# Altium schematic sheet SCH005 - VSC742x SERDES, VSC8574,clock.SchDoc
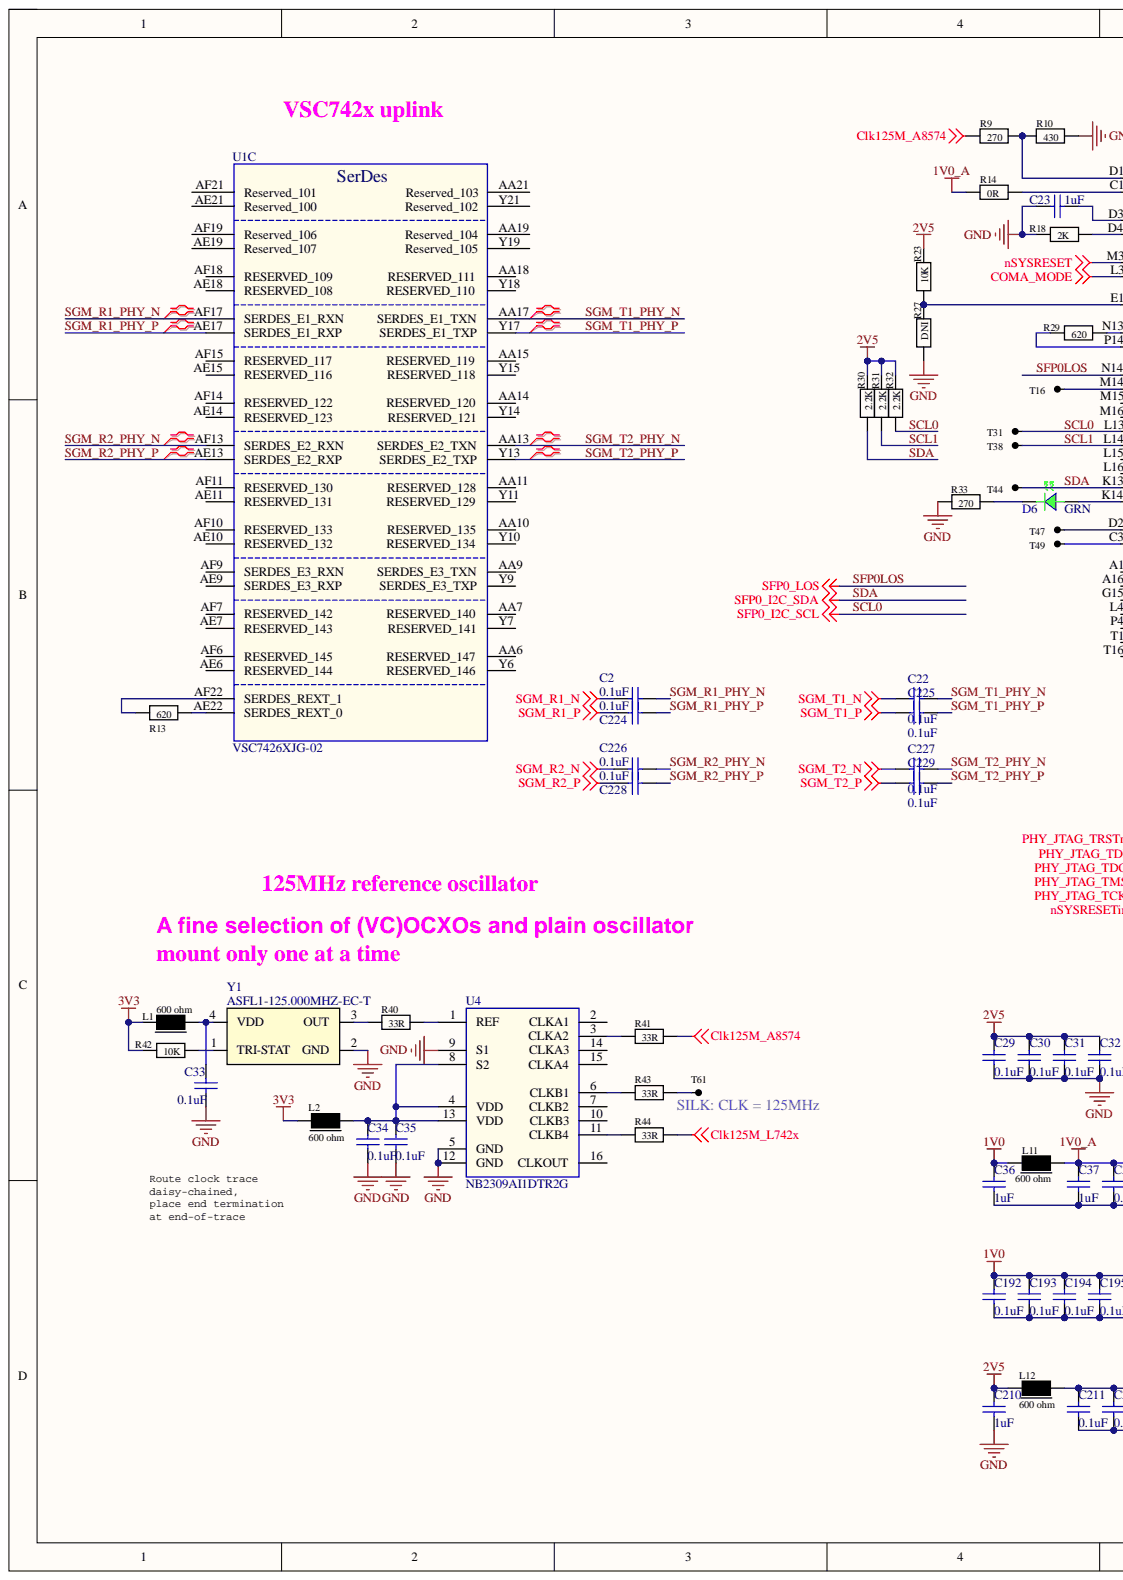
[diagram p0: SCH005 - VSC742x SERDES, VSC8574,clock.SchDoc, left]
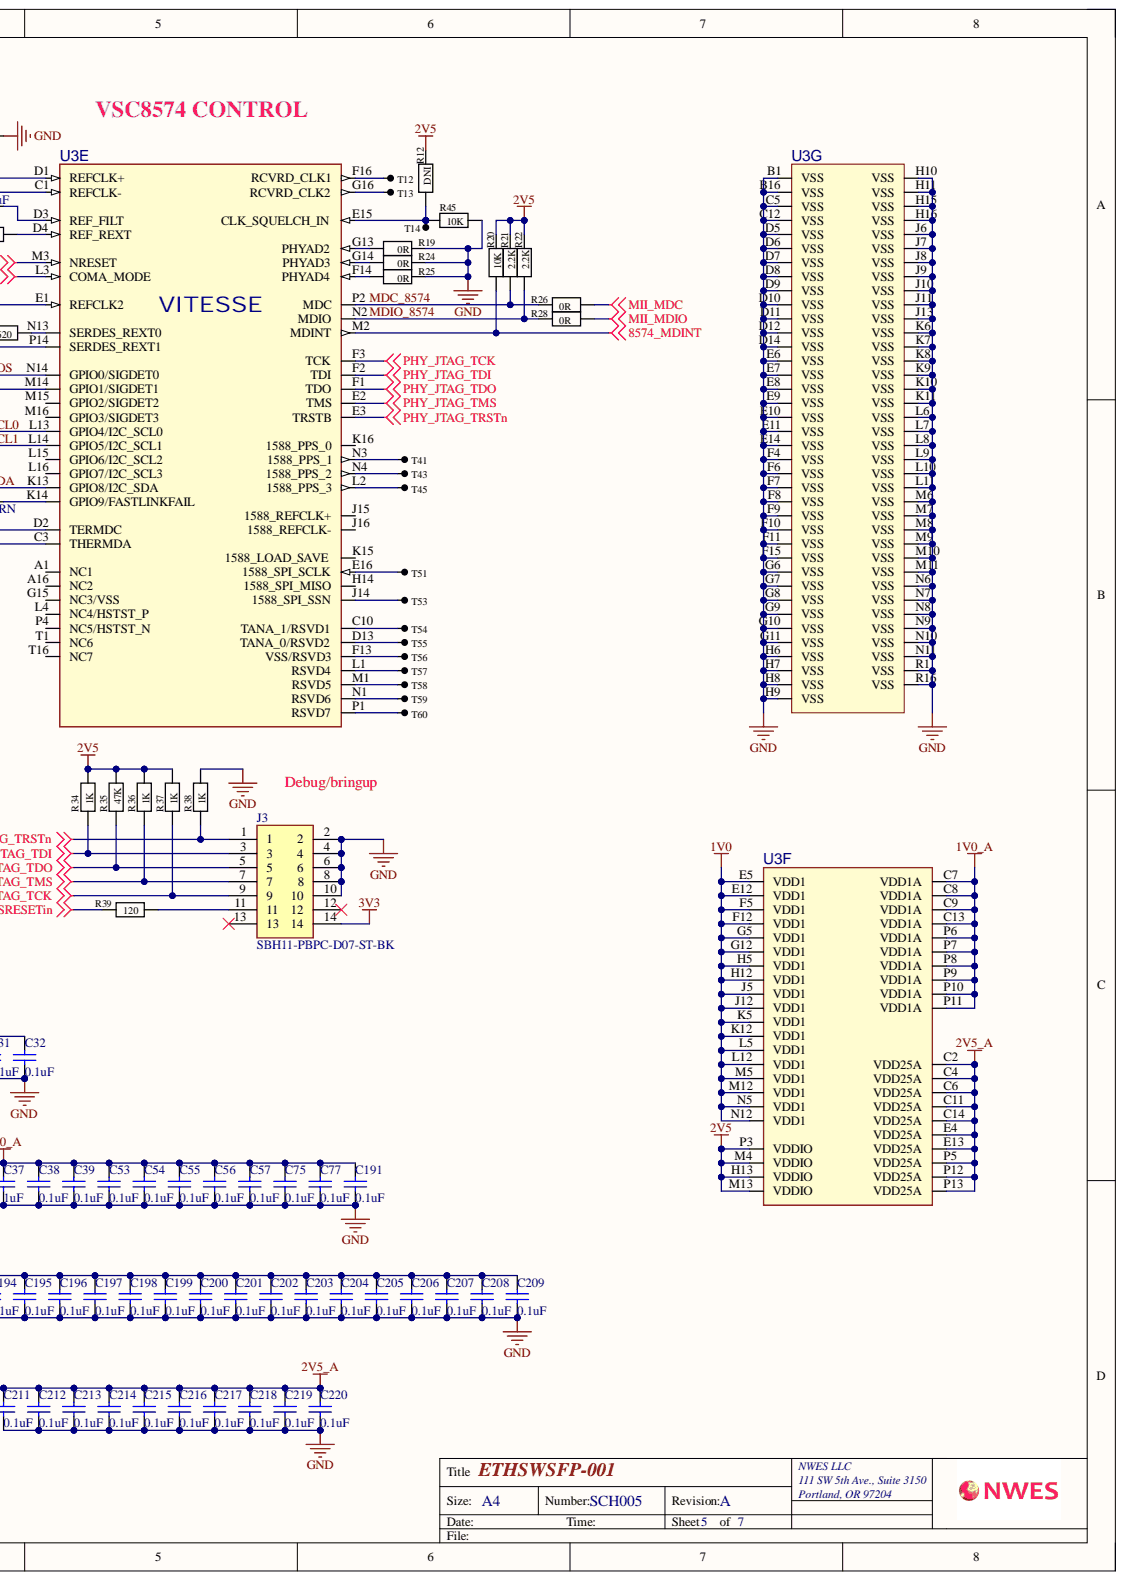
[diagram p1: SCH005 - VSC742x SERDES, VSC8574,clock.SchDoc, right]

## Parts
C2  0.1uF  [CAPC1005X55USR]
C22  0.1uF  [CAPC1005X55USR]
C23  1uF  [CAPC1005X61USR]
C29  0.1uF  [CAPC1005X61USR]
C30  0.1uF  [CAPC1005X61USR]
C31  0.1uF  [CAPC1005X61USR]
C32  0.1uF  [CAPC1005X61USR]
C33  0.1uF  [CAPC1005X61USR]
C34  0.1uF  [CAPC1005X61USR]
C35  0.1uF  [CAPC1005X61USR]
C36  1uF  [CAPC1005X61USR]
C37  1uF  [CAPC1005X61USR]
C38  0.1uF  [CAPC1005X61USR]
C39  0.1uF  [CAPC1005X61USR]
C53  0.1uF  [CAPC1005X61USR]
C54  0.1uF  [CAPC1005X61USR]
C55  0.1uF  [CAPC1005X61USR]
C56  0.1uF  [CAPC1005X61USR]
C57  0.1uF  [CAPC1005X61USR]
C75  0.1uF  [CAPC1005X61USR]
C77  0.1uF  [CAPC1005X61USR]
C191  0.1uF  [CAPC1005X61USR]
C192  0.1uF  [CAPC1005X61USR]
C193  0.1uF  [CAPC1005X61USR]
C194  0.1uF  [CAPC1005X61USR]
C195  0.1uF  [CAPC1005X61USR]
C196  0.1uF  [CAPC1005X61USR]
C197  0.1uF  [CAPC1005X61USR]
C198  0.1uF  [CAPC1005X61USR]
C199  0.1uF  [CAPC1005X61USR]
C200  0.1uF  [CAPC1005X61USR]
C201  0.1uF  [CAPC1005X61USR]
C202  0.1uF  [CAPC1005X61USR]
C203  0.1uF  [CAPC1005X61USR]
C204  0.1uF  [CAPC1005X61USR]
C205  0.1uF  [CAPC1005X61USR]
C206  0.1uF  [CAPC1005X61USR]
C207  0.1uF  [CAPC1005X61USR]
C208  0.1uF  [CAPC1005X61USR]
C209  0.1uF  [CAPC1005X61USR]
C210  1uF  [CAPC1005X61USR]
C211  0.1uF  [CAPC1005X61USR]
C212  0.1uF  [CAPC1005X61USR]
C213  0.1uF  [CAPC1005X61USR]
C214  0.1uF  [CAPC1005X61USR]
C215  0.1uF  [CAPC1005X61USR]
C216  0.1uF  [CAPC1005X61USR]
C217  0.1uF  [CAPC1005X61USR]
C218  0.1uF  [CAPC1005X61USR]
C219  0.1uF  [CAPC1005X61USR]
C220  0.1uF  [CAPC1005X61USR]
C224  0.1uF  [CAPC1005X55USR]
C225  0.1uF  [CAPC1005X55USR]
C226  0.1uF  [CAPC1005X55USR]
C227  0.1uF  [CAPC1005X55USR]
C228  0.1uF  [CAPC1005X55USR]
C229  0.1uF  [CAPC1005X55USR]
D6  GRN  [LED_0603]
J3  SBH11-PBPC-D07-ST-BK  [SULLINS_SBH11-PBPC-D07-ST-BK]
L1  600 ohm  [0603_FB]
L2  600 ohm  [0603_FB]
L11  600 ohm  [0603_FB]
L12  600 ohm  [0603_FB]
R9  270  [RESC1005X40USR]
R10  430  [RESC1005X40USR]
R12  DNI  [RESC1005X40USR]
R13  620  [RESC1005X40USR]
R14  0R  [RESC1005X40USR]
R18  2K  [RESC1005X40USR]
R19  0R  [RESC1005X40USR]
R20  10K  [RESC1005X40USR]
R21  2.2K  [RESC1005X40USR]
R22  2.2K  [RESC1005X40USR]
R23  10K  [RESC1005X40USR]
R24  0R  [RESC1005X40USR]
R25  0R  [RESC1005X40USR]
R26  0R  [RESC1005X40USR]
R27  DNI  [RESC1005X40USR]
R28  0R  [RESC1005X40USR]
R29  620  [RESC1005X40USR]
R30  2.2K  [RESC1005X40USR]
R31  2.2K  [RESC1005X40USR]
R32  2.2K  [RESC1005X40USR]
R33  270  [RESC1005X40USR]
R34  1K  [RESC1005X40USR]
R35  47K  [RESC1005X40USR]
R36  1K  [RESC1005X40USR]
R37  1K  [RESC1005X40USR]
R38  1K  [RESC1005X40USR]
R39  120  [RESC1005X40USR]
R40  33R  [RESC1005X40USR]
R41  33R  [RESC1005X40USR]
R42  10K  [RESC1005X40USR]
R43  33R  [RESC1005X40USR]
R44  33R  [RESC1005X40USR]
R45  10K  [RESC1005X40USR]
T12  TP_S  [TP 1mm]  (value not drawn)  (no BOM)
T13  TP_S  [TP 1mm]  (value not drawn)  (no BOM)
T14  TP_S  [TP 1mm]  (value not drawn)  (no BOM)
T16  TP_S  [TP 1mm]  (value not drawn)  (no BOM)
T31  TP_S  [TP 1mm]  (value not drawn)  (no BOM)
T38  TP_S  [TP 1mm]  (value not drawn)  (no BOM)
T41  TP_S  [TP 1mm]  (value not drawn)  (no BOM)
T43  TP_S  [TP 1mm]  (value not drawn)  (no BOM)
T44  TP_S  [TP 1mm]  (value not drawn)  (no BOM)
T45  TP_S  [TP 1mm]  (value not drawn)  (no BOM)
T47  TP_S  [TP 1mm]  (value not drawn)  (no BOM)
T49  TP_S  [TP 1mm]  (value not drawn)  (no BOM)
T51  TP_S  [TP 1mm]  (value not drawn)  (no BOM)
T53  TP_S  [TP 1mm]  (value not drawn)  (no BOM)
T54  TP_S  [TP 1mm]  (value not drawn)  (no BOM)
T55  TP_S  [TP 1mm]  (value not drawn)  (no BOM)
T56  TP_S  [TP 1mm]  (value not drawn)  (no BOM)
T57  TP_S  [TP 1mm]  (value not drawn)  (no BOM)
T58  TP_S  [TP 1mm]  (value not drawn)  (no BOM)
T59  TP_S  [TP 1mm]  (value not drawn)  (no BOM)
T60  TP_S  [TP 1mm]  (value not drawn)  (no BOM)
T61  TP_S  [TP 1mm]  (value not drawn)  (no BOM)
U1  VSC7426XJG-02  [BGA672C100P26X26_2700X2700X244]  (part C)
U3  VSC8574XKS-01  [256-BGA_1700X1700]  (value not drawn)  (parts E,F,G)
U4  NB2309AI1DTR2G  [NB2309AI1DT_TSSOP-16_ONS]
Y1  ASFL1-125.000MHZ-EC-T  [XTAL_50X32X130]

## Nets
1V0: C36.2 C192.1 C193.1 C194.1 C195.1 C196.1 C197.1 C198.1 C199.1 C200.1 C201.1 C202.1 C203.1 C204.1 C205.1 C206.1 C207.1 C208.1 C209.1 L11.1 U3.E5(VDD1) U3.E12(VDD1) U3.F5(VDD1) U3.F12(VDD1) U3.G5(VDD1) U3.G12(VDD1) U3.H5(VDD1) U3.H12(VDD1) U3.J5(VDD1) U3.J12(VDD1) U3.K5(VDD1) U3.K12(VDD1) U3.L5(VDD1) U3.L12(VDD1) U3.M5(VDD1) U3.M12(VDD1) U3.N5(VDD1) U3.N12(VDD1)
1V0_A: C37.2 C38.1 C39.1 C53.1 C54.1 C55.1 C56.1 C57.1 C75.1 C77.1 C191.1 L11.2 R14.2 U3.C7(VDD1A) U3.C8(VDD1A) U3.C9(VDD1A) U3.C13(VDD1A) U3.P6(VDD1A) U3.P7(VDD1A) U3.P8(VDD1A) U3.P9(VDD1A) U3.P10(VDD1A) U3.P11(VDD1A)
2V5: C29.1 C30.1 C31.1 C32.1 C210.2 L12.2 R12.1 R20.2 R21.2 R22.2 R23.1 R30.1 R31.1 R32.1 R34.1 R35.1 R36.1 R37.1 U3.H13(VDDIO) U3.M4(VDDIO) U3.M13(VDDIO) U3.P3(VDDIO)
2V5_A: C211.1 C212.1 C213.1 C214.1 C215.1 C216.1 C217.1 C218.1 C219.1 C220.1 L12.1 U3.C2(VDD25A) U3.C4(VDD25A) U3.C6(VDD25A) U3.C11(VDD25A) U3.C14(VDD25A) U3.E4(VDD25A) U3.E13(VDD25A) U3.P5(VDD25A) U3.P12(VDD25A) U3.P13(VDD25A)
3V3: J3.14 L1.1 L2.1 R42.1
8574_MDINT: R20.1 U3.M2(MDINT)
Clk125M_A8574: R9.2 R41.1
Clk125M_L742x: R44.1
COMA_MODE: U3.L3(COMA_MODE)
GND: C23.2 C29.2 C30.2 C31.2 C32.2 C33.1 C34.1 C35.1 C36.1 C37.1 C38.2 C39.2 C53.2 C54.2 C55.2 C56.2 C57.2 C75.2 C77.2 C191.2 C192.2 C193.2 C194.2 C195.2 C196.2 C197.2 C198.2 C199.2 C200.2 C201.2 C202.2 C203.2 C204.2 C205.2 C206.2 C207.2 C208.2 C209.2 C210.1 C211.2 C212.2 C213.2 C214.2 C215.2 C216.2 C217.2 C218.2 C219.2 C220.2 J3.2 J3.4 J3.6 J3.8 J3.10 R10.1 R18.2 R19.1 R24.1 R25.1 R27.2 R33.1 R38.1 R45.1 U3.B1(VSS) U3.B16(VSS) U3.C5(VSS) U3.C12(VSS) U3.D5(VSS) U3.D6(VSS) U3.D7(VSS) U3.D8(VSS) U3.D9(VSS) U3.D10(VSS) U3.D11(VSS) U3.D12(VSS) U3.D14(VSS) U3.E6(VSS) U3.E7(VSS) U3.E8(VSS) U3.E9(VSS) U3.E10(VSS) U3.E11(VSS) U3.E14(VSS) U3.F4(VSS) U3.F6(VSS) U3.F7(VSS) U3.F8(VSS) U3.F9(VSS) U3.F10(VSS) U3.F11(VSS) U3.F15(VSS) U3.G6(VSS) U3.G7(VSS) U3.G8(VSS) U3.G9(VSS) U3.G10(VSS) U3.G11(VSS) U3.H6(VSS) U3.H7(VSS) U3.H8(VSS) U3.H9(VSS) U3.H10(VSS) U3.H11(VSS) U3.H15(VSS) U3.H16(VSS) U3.J6(VSS) U3.J7(VSS) U3.J8(VSS) U3.J9(VSS) U3.J10(VSS) U3.J11(VSS) U3.J13(VSS) U3.K6(VSS) U3.K7(VSS) U3.K8(VSS) U3.K9(VSS) U3.K10(VSS) U3.K11(VSS) U3.L6(VSS) U3.L7(VSS) U3.L8(VSS) U3.L9(VSS) U3.L10(VSS) U3.L11(VSS) U3.M6(VSS) U3.M7(VSS) U3.M8(VSS) U3.M9(VSS) U3.M10(VSS) U3.M11(VSS) U3.N6(VSS) U3.N7(VSS) U3.N8(VSS) U3.N9(VSS) U3.N10(VSS) U3.N11(VSS) U3.R1(VSS) U3.R16(VSS) U4.5(GND) U4.9(S1) U4.12(GND) Y1.2(GND)
MII_MDC: R26.1
MII_MDIO: R28.1
nSYSRESET: U3.M3(NRESET)
nSYSRESETin: R39.1
PHY_JTAG_TCK: J3.9 R37.2 U3.F3(TCK)
PHY_JTAG_TDI: J3.3 R34.2 U3.F2(TDI)
PHY_JTAG_TDO: J3.5 R35.2 U3.F1(TDO)
PHY_JTAG_TMS: J3.7 R36.2 U3.E2(TMS)
PHY_JTAG_TRSTn: J3.1 R38.2 U3.E3(TRSTB)
SGM_R1_N: C2.2
SGM_R1_P: C224.2
SGM_R2_N: C226.2
SGM_R2_P: C228.2
SGM_T1_N: C22.1
SGM_T1_P: C225.1
SGM_T2_N: C227.1
SGM_T2_P: C229.1
MDC_8574: R21.1 R26.2 U3.P2(MDC)
MDIO_8574: R22.1 R28.2 U3.N2(MDIO)
SCL0: R32.2 T31.1 U3.L13(GPIO4/I2C_SCL0)
SCL1: R31.2 T38.1 U3.L14(GPIO5/I2C_SCL1)
SDA: R30.2 T44.1 U3.K13(GPIO8/I2C_SDA)
SFP0LOS: U3.N14(GPIO0/SIGDET0)
SGM_R1_PHY_N: C2.1 U1.AF17(SERDES_E1_RXN)
SGM_R1_PHY_P: C224.1 U1.AE17(SERDES_E1_RXP)
SGM_R2_PHY_N: C226.1 U1.AF13(SERDES_E2_RXN)
SGM_R2_PHY_P: C228.1 U1.AE13(SERDES_E2_RXP)
SGM_T1_PHY_N: C22.2 U1.AA17(SERDES_E1_TXN)
SGM_T1_PHY_P: C225.2 U1.Y17(SERDES_E1_TXP)
SGM_T2_PHY_N: C227.2 U1.AA13(SERDES_E2_TXN)
SGM_T2_PHY_P: C229.2 U1.Y13(SERDES_E2_TXP)
NetC23_1: C23.1 U3.D3(REF_FILT)
NetC33_2: C33.2 L1.2 Y1.4(VDD)
NetC34_2: C34.2 C35.2 L2.2 U4.4(VDD) U4.8(S2) U4.13(VDD)
NetD6_1: D6.1 U3.K14(GPIO9/FASTLINKFAIL)
NetD6_2: D6.2 R33.2
NetJ3_11: J3.11 R39.2
NetR9_1: R9.1 R10.2 U3.D1(REFCLK+)
NetR12_2: R12.2 R45.2 T14.1 U3.E15(CLK_SQUELCH_IN)
NetR13_1: R13.1 U1.AE22(SERDES_REXT_0)
NetR13_2: R13.2 U1.AF22(SERDES_REXT_1)
NetR14_1: R14.1 U3.C1(REFCLK-)
NetR18_1: R18.1 U3.D4(REF_REXT)
NetR19_2: R19.2 U3.G13(PHYAD2)
NetR23_2: R23.2 R27.1 U3.E1(REFCLK2)
NetR24_2: R24.2 U3.G14(PHYAD3)
NetR25_2: R25.2 U3.F14(PHYAD4)
NetR29_1: R29.1 U3.N13(SERDES_REXT0)
NetR29_2: R29.2 U3.P14(SERDES_REXT1)
NetR40_1: R40.1 U4.1(REF)
NetR40_2: R40.2 Y1.3(OUT)
NetR41_2: R41.2 U4.3(CLKA2)
NetR42_2: R42.2 Y1.1(TRI-STAT)
NetR43_1: R43.1 T61.1
NetR43_2: R43.2 U4.6(CLKB1)
NetR44_2: R44.2 U4.11(CLKB4)
NetT12_1: T12.1 U3.F16(RCVRD_CLK1)
NetT13_1: T13.1 U3.G16(RCVRD_CLK2)
NetT16_1: T16.1 U3.M14(GPIO1/SIGDET1)
NetT41_1: T41.1 U3.N3(1588_PPS_1)
NetT43_1: T43.1 U3.N4(1588_PPS_2)
NetT45_1: T45.1 U3.L2(1588_PPS_3)
NetT47_1: T47.1 U3.D2(TERMDC)
NetT49_1: T49.1 U3.C3(THERMDA)
NetT51_1: T51.1 U3.E16(1588_SPI_SCLK)
NetT53_1: T53.1 U3.J14(1588_SPI_SSN)
NetT54_1: T54.1 U3.C10(TANA_1/RSVD1)
NetT55_1: T55.1 U3.D13(TANA_0/RSVD2)
NetT56_1: T56.1 U3.F13(VSS/RSVD3)
NetT57_1: T57.1 U3.L1(RSVD4)
NetT58_1: T58.1 U3.M1(RSVD5)
NetT59_1: T59.1 U3.N1(RSVD6)
NetT60_1: T60.1 U3.P1(RSVD7)

Not connected: J3 12 13; U1 AA6(RESERVED_147) AA7(RESERVED_140) AA9(SERDES_E3_TXN) AA10(RESERVED_135) AA11(RESERVED_128) AA14(RESERVED_120) AA15(RESERVED_119) AA18(RESERVED_111) AA19(Reserved_104) AA21(Reserved_103) AE6(RESERVED_144) AE7(RESERVED_143) AE9(SERDES_E3_RXP) AE10(RESERVED_132) AE11(RESERVED_131) AE14(RESERVED_123) AE15(RESERVED_116) AE18(RESERVED_108) AE19(Reserved_107) AE21(Reserved_100) AF6(RESERVED_145) AF7(RESERVED_142) AF9(SERDES_E3_RXN) AF10(RESERVED_133) AF11(RESERVED_130) AF14(RESERVED_122) AF15(RESERVED_117) AF18(RESERVED_109) AF19(Reserved_106) AF21(Reserved_101) Y6(RESERVED_146) Y7(RESERVED_141) Y9(SERDES_E3_TXP) Y10(RESERVED_134) Y11(RESERVED_129) Y14(RESERVED_121) Y15(RESERVED_118) Y18(RESERVED_110) Y19(Reserved_105) Y21(Reserved_102); U3 A1(NC1) A16(NC2) G15(NC3/VSS) H14(1588_SPI_MISO) J15(1588_REFCLK+) J16(1588_REFCLK-) K15(1588_LOAD_SAVE) K16(1588_PPS_0) L4(NC4/HSTST_P) L15(GPIO6/I2C_SCL2) L16(GPIO7/I2C_SCL3) M15(GPIO2/SIGDET2) M16(GPIO3/SIGDET3) P4(NC5/HSTST_N) T1(NC6) T16(NC7); U4 2(CLKA1) 7(CLKB2) 10(CLKB3) 14(CLKA3) 15(CLKA4) 16(CLKOUT)

## Library data of the parts
C2 C22 C224-C229 = CAP CER 0.1UF 25V X5R 0402: Manufacturer: Samsung Electro-Mechanics; Manufacturer Part Number: CL05A104KA5NNNC; Component Kind: Standard; Description: CAP CER 0.1UF 25V X5R 0402; Height: 0.55mm; Package: 0402; Supplier 1: Digi-Key; Supplier Part Number 1: 1276-1043-1-ND; value: 0.1uF; Library Name: Radar FPGA RF.SCHLIB
C23 C36 C37 C210 = CAP CER 1UF 25V X5R 0402: Manufacturer: Murata Electronics; Manufacturer Part Number: GRM155R61E105MA12D; Component Kind: Standard; Description: CAP CER 1UF 25V X5R 0402; Package: 0402; Supplier 1: Digi-Key; Supplier Part Number 1: 490-10018-1-ND; value: 1uF; Library Name: 15-port switch V1.SCHLIB
C29-C35 C38 C39 C53-C57 C75 C77 C191-C209 C211-C220 = CAP CER 0.1UF 50V X7R 0402: Manufacturer: Murata Electronics; Manufacturer Part Number: GRM155R71H104KE14D; Component Kind: Standard; Description: CAP CER 0.1UF 50V X7R 0402; Package: 0402; Supplier 1: Digi-Key; Supplier Part Number 1: 490-10700-2-ND; value: 0.1uF; Library Name: 15-port switch V1.SCHLIB
D6 = 0603LED_GRN: LED GREEN CLEAR CHIP 0603 SMD; Manufacturer: Lite-On Inc.; Manufacturer Part Number: LTST-C191GKT; Unit Cost, Qty 1: $0.68; Unit Cost, Qty 500: $0.293; Component Kind: Standard; Supplier 1: Digi-Key; Supplier Part Number 1: 160-1443-1-ND; Value: GRN; Library Name: 15-port switch V1.SCHLIB
J3 = SBH11-PBPC-D07-ST-BK: Connector Header Through Hole 14 position 0.100 _2.54mm_; Manufacturer: Sullins Connector Solutions; Component Kind: Standard; Manufacturer Part Number: SBH11-PBPC-D07-ST-BK; Supplier 1: Digi-Key; Supplier Part Number 1: S9170-ND; Value: SBH11-PBPC-D07-ST-BK; Library Name: 15-port switch V1.SCHLIB
L1 L2 L11 L12 = FERRITE BEAD 600 OHM 0603 1LN: Manufacturer: Würth Elektronik; Manufacturer Part Number: 742863160; Component Kind: Standard; Description: FERRITE BEAD 600 OHM 0603 1LN; Package: 0603; Supplier 1: Digi-Key; Supplier Part Number 1: 732-2389-1-ND; Value: 600 ohm; Library Name: 15-port switch V1.SCHLIB
R9 R33 = RES 270 OHM 1% 1/16W 0402: Manufacturer: Yageo; Manufacturer Part Number: RC0402FR-07270RL; Component Kind: Standard; Description: RES 270 OHM 1% 1/16W 0402; Height: 0.40mm; Package: 0402; Supplier 1: Digi-Key; Supplier Part Number 1: 311-270LRCT-ND; Value: 270; Library Name: 15-port switch V1.SCHLIB
R10 = RES 430 OHM 1% 1/16W 0402: Manufacturer: Yageo; Manufacturer Part Number: RC0402FR-07430RL; Component Kind: Standard; Description: RES 430 OHM 1% 1/16W 0402; Height: 0.40mm; Package: 0402; Supplier 1: Digi-Key; Supplier Part Number 1: 311-430LRCT-ND; Value: 430; Library Name: 15-port switch V1.SCHLIB
R12 R27 = RES DNI: RES 0 OHM JUMPER 1/16W 0402; Manufacturer: Yageo; Manufacturer Part Number: RC0402FR-070RL; Value: 0R; Component Kind: Standard; Height: 0.40mm; Package: 0402; Supplier 1: Digi-Key; Supplier Part Number 1: 311-0.0LRCT-ND; Library Name: 15-port switch V1.SCHLIB
R13 R29 = RES 620 OHM 1% 1/16W 0402: Manufacturer: Yageo; Manufacturer Part Number: RC0402FR-07620RL; Component Kind: Standard; Description: RES 620 OHM 1% 1/16W 0402; Height: 0.40mm; Package: 0402; Supplier 1: Digi-Key; Supplier Part Number 1: 311-620LRCT-ND; Value: 620; Library Name: 15-port switch V1.SCHLIB
R14 R19 R24-R26 R28 = RES 0 OHM JUMPER 1/16W 0402: Manufacturer: Yageo; Manufacturer Part Number: RC0402FR-070RL; Component Kind: Standard; Description: RES 0 OHM JUMPER 1/16W 0402; Height: 0.40mm; Package: 0402; Supplier 1: Digi-Key; Supplier Part Number 1: 311-0.0LRCT-ND; Value: 0R; Library Name: 15-port switch V1.SCHLIB
R18 = RES 2K OHM 1% 1/16W 0402: Manufacturer: Yageo; Manufacturer Part Number: RC0402FR-072KL; Component Kind: Standard; Description: RES 2K OHM 1% 1/16W 0402; Height: 0.40mm; Package: 0402; Supplier 1: Digi-Key; Supplier Part Number 1: 311-2KLRCT-ND; Value: 2K; Library Name: 15-port switch V1.SCHLIB
R20 R23 R42 R45 = RES SMD 10K OHM 1% 1/16W 0402: Manufacturer: Yageo; Manufacturer Part Number: AC0402FR-0710KL; Component Kind: Standard; Description: RES SMD 10K OHM 1% 1/16W 0402; Height: 0.40mm; Package: 0402; Supplier 1: Digi-Key; Supplier Part Number 1: YAG3436TR-ND; Value: 10K; Library Name: 15-port switch V1.SCHLIB
R21 R22 R30-R32 = RES 2.2K OHM 1% 1/16W 0402: Manufacturer: Yageo; Manufacturer Part Number: RC0402FR-072K2L; Component Kind: Standard; Description: RES 2.2K OHM 1% 1/16W 0402; Height: 0.40mm; Package: 0402; Supplier 1: Digi-Key; Supplier Part Number 1: 311-2.20KLRCT-ND; Value: 2.2K; Library Name: 15-port switch V1.SCHLIB
R34 R36-R38 = RES SMD 1K OHM 1% 1/16W 0402: Manufacturer: Yageo; Manufacturer Part Number: RC0402FR-071KP; Component Kind: Standard; Description: RES SMD 1K OHM 1% 1/16W 0402; Height: 0.40mm; Package: 0402; Supplier 1: Digi-Key; Supplier Part Number 1: YAG1254CT-ND; Value: 1K; Library Name: 15-port switch V1.SCHLIB
R35 = RES 47K OHM 1% 1/16W 0402: Manufacturer: Stackpole Electronics Inc; Manufacturer Part Number: RMCF0402FT47K0; Component Kind: Standard; Description: RES 47K OHM 1% 1/16W 0402; Height: 0.40mm; Package: 0402; Supplier 1: Digi-Key; Supplier Part Number 1: RMCF0402FT47K0CT-ND; Value: 47K; Library Name: 15-port switch V1.SCHLIB
R39 = RES 120 OHM 1% 1/16W 0402: Manufacturer: Yageo; Manufacturer Part Number: RC0402FR-07120RL; Component Kind: Standard; Description: RES 120 OHM 1% 1/16W 0402; Height: 0.40mm; Package: 0402; Supplier 1: Digi-Key; Supplier Part Number 1: 311-120LRCT-ND; Value: 120; Library Name: 15-port switch V1.SCHLIB
R40 R41 R43 R44 = RES 33 OHM 1% 1/16W 0402: Manufacturer: Yageo; Manufacturer Part Number: RC0402FR-0733RL; Component Kind: Standard; Description: RES 33 OHM 1% 1/16W 0402; Height: 0.40mm; Package: 0402; Supplier 1: Digi-Key; Supplier Part Number 1: 311-33.0LRCT-ND; Value: 33R; Library Name: 15-port switch V1.SCHLIB
T12-T14 T16 T31 T38 T41 T43-T45 T47 T49 T51 T53-T61 = TP_S: test point; Library Name: 26-port switch.SCHLIB
U1 = VSC7426XJG-02: IC TELECOM INTERFACE 672BGA; Manufacturer: Microchip Technology; Geometry.Height: 2.44mm; Component Kind: Standard; Manufacturer Part Number: VSC7426XJG-02; Supplier Part Number 1: VSC7426XJG-02-ND; Library Name: 15-port switch V1.SCHLIB
U3 = VSC8574: IC TELECOM INTERFACE QUAD; Manufacturer: Microchip Technology; Component Kind: Standard; Manufacturer Part Number: VSC8574XKS-01; Supplier 1: Microchip; Supplier Part Number 1: VSC8574XKS-01; Library Name: 15-port switch V1.SCHLIB
U4 = NB2309AI1DTR2G: IC BUFFER CLK 9OUT 3.3V 16-TSSOP; Manufacturer: ON Semiconductor; Component Kind: Standard; Manufacturer Part Number: NB2309AI1DTR2G; Supplier 1: Mouser; Supplier Part Number 1: 863-NB2309AI1DTR2G; value: NB2309AI1DTR2G; Library Name: 15-port switch V1.SCHLIB
Y1 = ASFL1-125.000MHZ-EC-T: XTAL OSC XO 125.0000MHZ HCMOS; Manufacturer: Abracon LLC; Component Kind: Standard; Manufacturer Part Number: ASFL1-125.000MHZ-EC-T; Supplier 1: Digi-Key; Supplier Part Number 1: 300-8272-1-ND; Copyright: Copyright (C) 2021 Ultra Librarian. All rights reserved.; Library Name: 15-port switch V1.SCHLIB
